# Altium schematic sheet power.SchDoc(power)
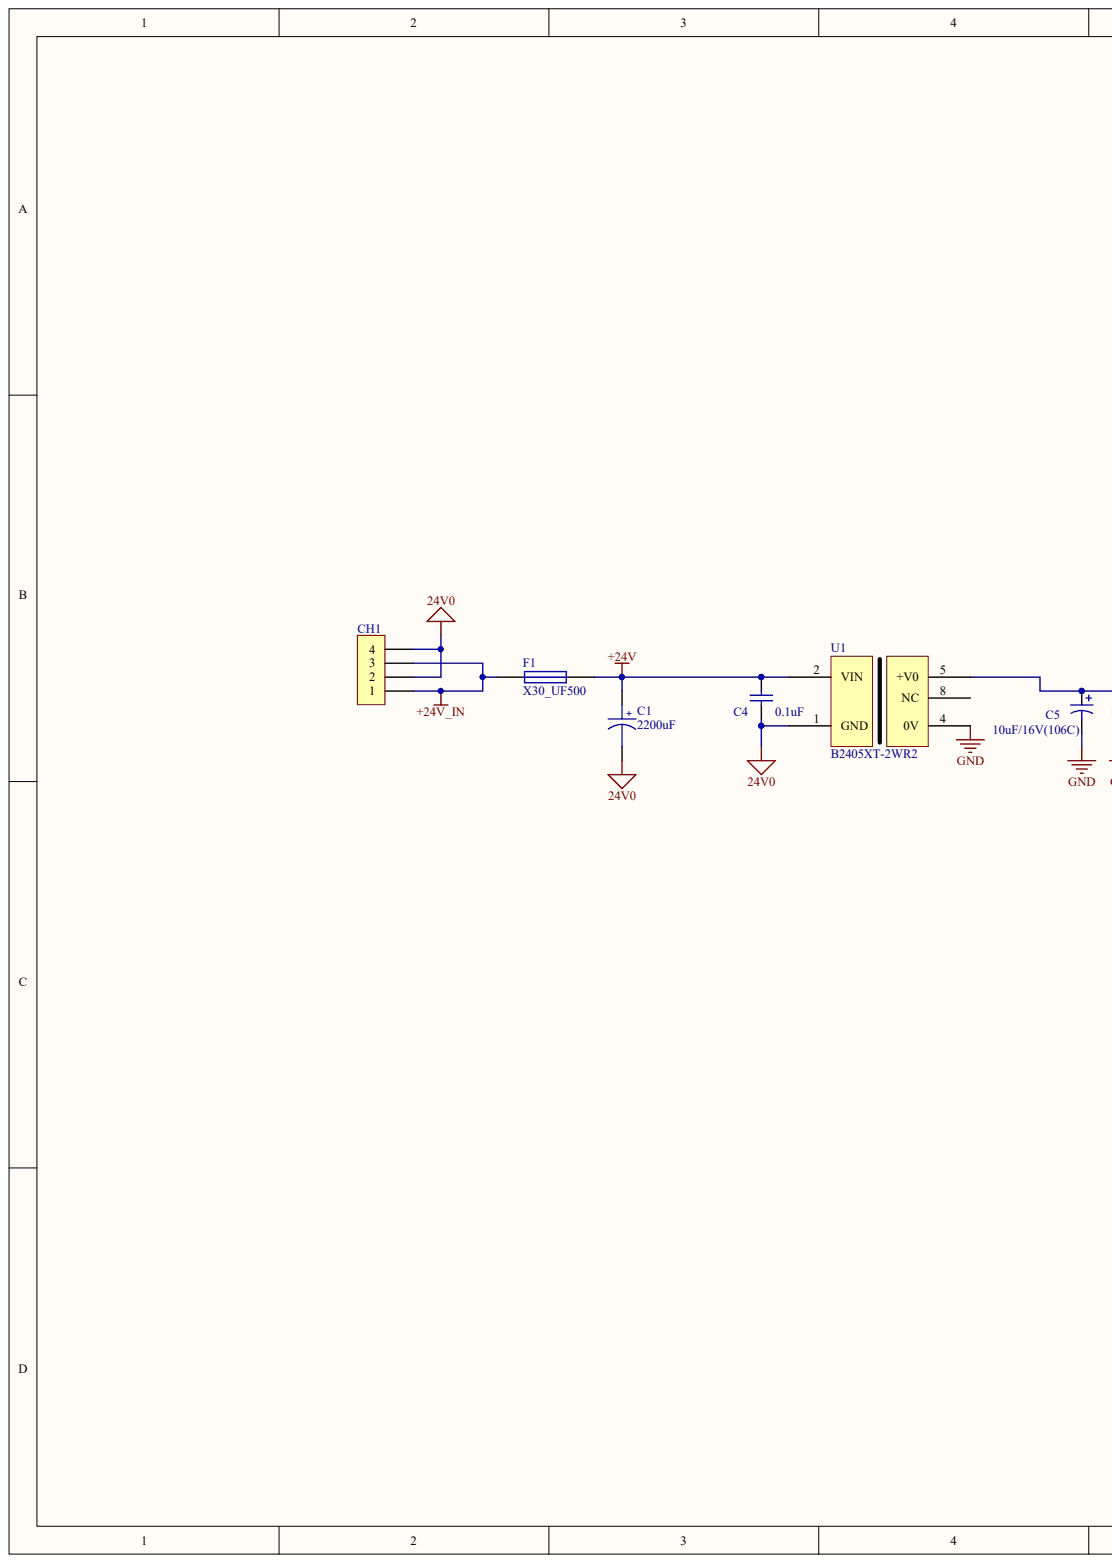
[diagram p0: power.SchDoc, left]
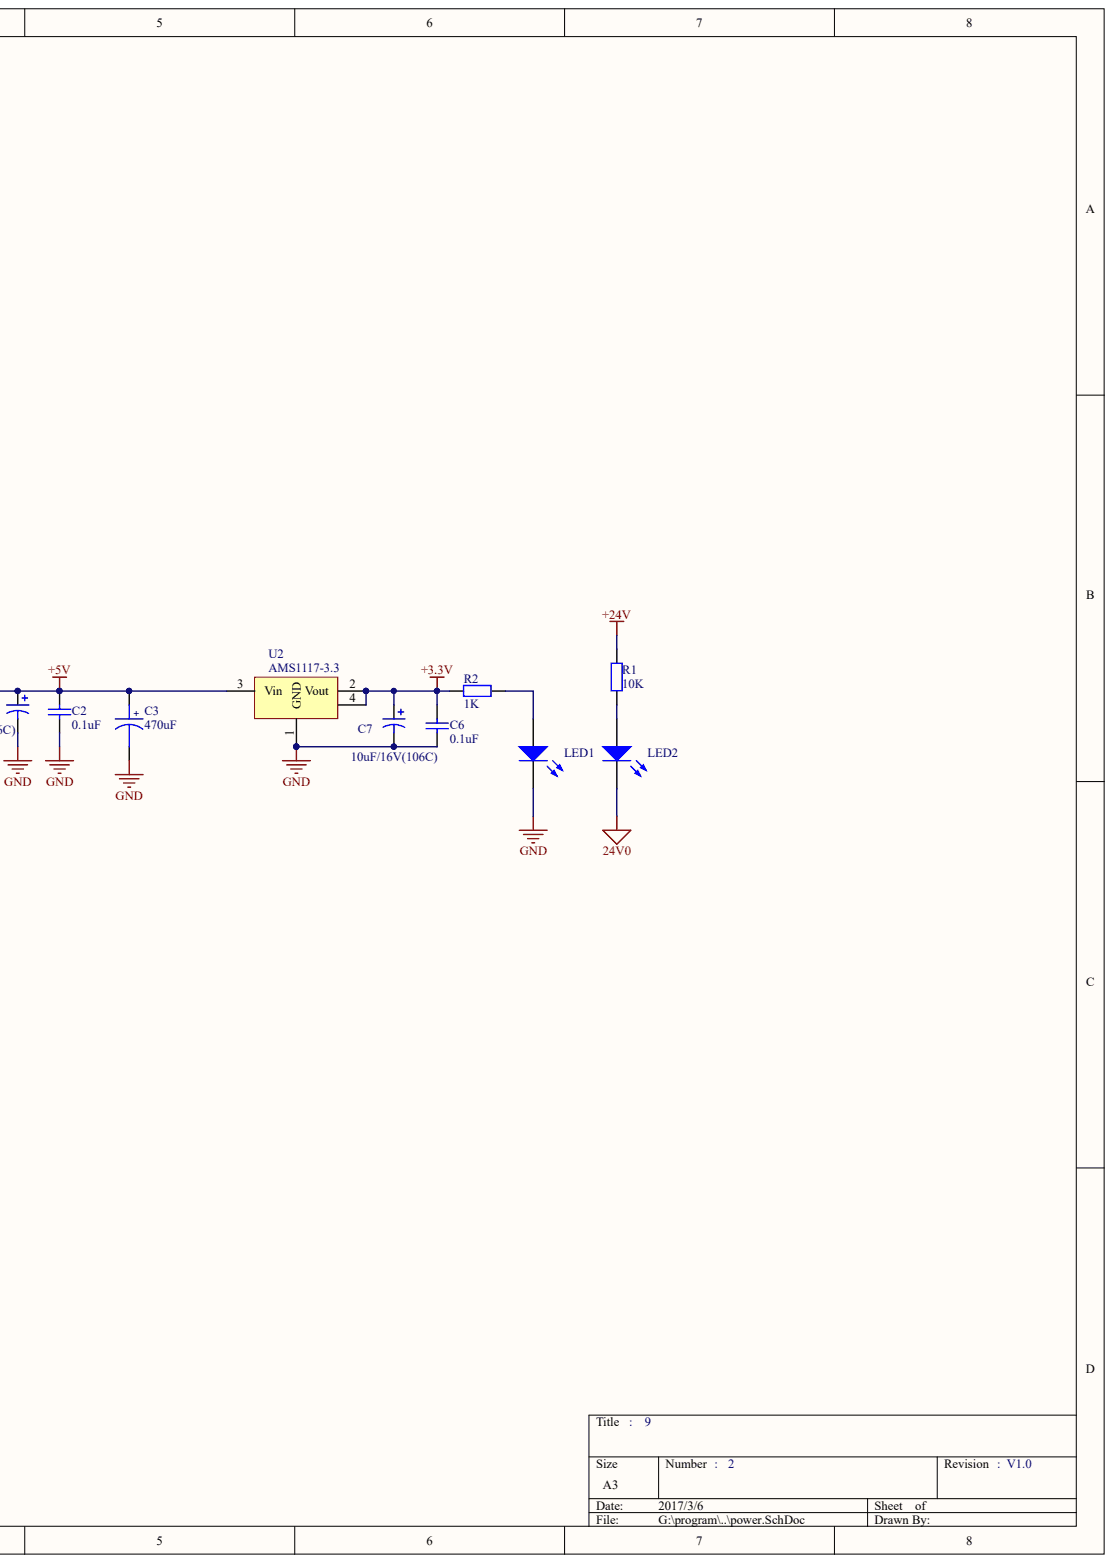
[diagram p1: power.SchDoc, right]

## Parts
C1  C_2200uF  (value not drawn)
C2  value 0.1uF  (hidden comment: Cap)
C3  C_2200uF  (value not drawn)
C4  value 0.1uF  (hidden comment: Cap)
C5  value 10uF/16V(106C)  (hidden comment: Cap Pol3)
C6  value 0.1uF  (hidden comment: Cap)
C7  value 10uF/16V(106C)  (hidden comment: Cap Pol3)
CH1  HEADER1X4  (value not drawn)
F1  X30_UF500
LED1  (no value)
LED2  (no value)
R1  value 10K  (hidden comment: Res2)
R2  value 1K  (hidden comment: Res2)
U1  B2405XT-2WR2
U2  AMS1117-3.3

## Nets
24V0: C1.2 C4.1 CH1.2 CH1.4 LED2.2 U1.1(GND)
+3.3V: C6.2 C7.1 R2.2 U2.2(Vout) U2.4
+5V: C2.2 C3.1 C5.1 U1.5(+V0) U2.3(Vin)
+24V: C1.1 C4.2 F1.2 R1.2 U1.2(VIN)
+24V_IN: CH1.1 CH1.3 F1.1
GND: C2.1 C3.2 C5.2 C6.1 C7.2 LED1.2 U1.4(0V) U2.1(GND)
NetLED1_1: LED1.1 R2.1
NetLED2_1: LED2.1 R1.1

Not connected: U1 8(NC)

## Library data of the parts
C1-C7 CH1 F1 LED1 LED2 R1 R2 U1 U2 = (no symbol name)
  C1: : 2200uF
  C2 C4 C6: Published: 8-Jun-2000; LatestRevisionDate: 17-Jul-2002; LatestRevisionNote: Re-released for DXP Platform.; PackageReference: RAD-0.3
  C3: : 470uF
  C5 C7: Published: 8-Jun-2000; LatestRevisionDate: 17-Jul-2002; LatestRevisionNote: Re-released for DXP Platform.; PackageReference: C0805
  F1: Published: 8-Jun-2000; LatestRevisionDate: 17-Jul-2002; LatestRevisionNote: Re-released for DXP Platform.; PackageReference: PIN-W2/E2.8
  LED1 LED2: Published: 8-Jun-2000; LatestRevisionDate: 17-Jul-2002; LatestRevisionNote: Re-released for DXP Platform.; PackageDocument: Feb-2002; PackageReference: 3.2X1.6X1.1
  R1 R2: Published: 8-Jun-2000; LatestRevisionDate: 17-Jul-2002; LatestRevisionNote: Re-released for DXP Platform.; PackageReference: AXIAL-0.4
  C2 C4-C7 F1 LED1 LED2 R1 R2: Publisher: Altium Limited
